# Altium schematic sheet MCU.SchDoc(MCU)
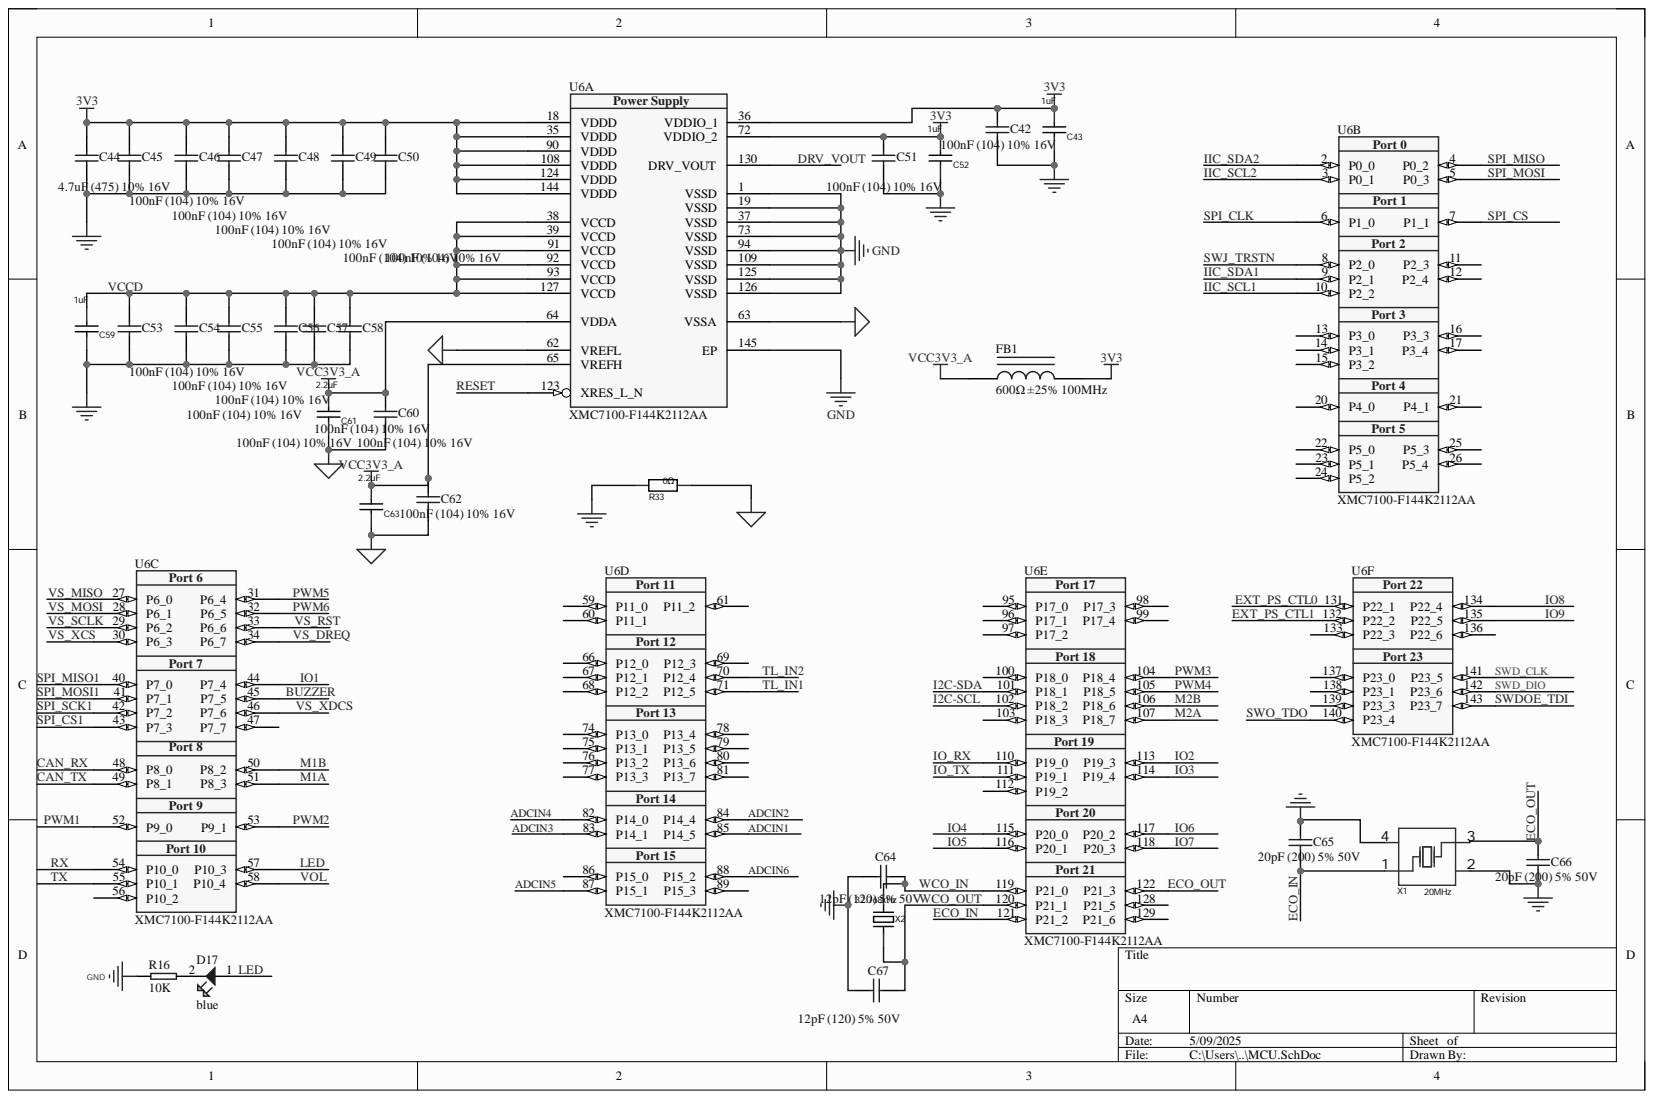
[diagram p0: MCU.SchDoc]

## Parts
C42  100nF (104) 10% 16V  [0402_C]
C43  1uF  Voltage Rated: 16V  [0402_C]
C44  4.7uF (475) 10% 16V  [0603_C]
C45  100nF (104) 10% 16V  [0402_C]
C46  100nF (104) 10% 16V  [0402_C]
C47  100nF (104) 10% 16V  [0402_C]
C48  100nF (104) 10% 16V  [0402_C]
C49  100nF (104) 10% 16V  [0402_C]
C50  100nF (104) 10% 16V  [0402_C]
C51  100nF (104) 10% 16V  [0402_C]
C52  1uF  Voltage Rated: 16V  [0402_C]
C53  100nF (104) 10% 16V  [0402_C]
C54  100nF (104) 10% 16V  [0402_C]
C55  100nF (104) 10% 16V  [0402_C]
C56  100nF (104) 10% 16V  [0402_C]
C57  100nF (104) 10% 16V  [0402_C]
C58  100nF (104) 10% 16V  [0402_C]
C59  1uF  [0402_C]
C60  100nF (104) 10% 16V  [0402_C]
C61  2.2uF  Voltage Rated: 16V  [0603_C]
C62  100nF (104) 10% 16V  [0402_C]
C63  2.2uF  [0603_C]
C64  12pF (120) 5% 50V  [0402_C]
C65  20pF (200) 5% 50V  [0402_C]
C66  20pF (200) 5% 50V  [0402_C]
C67  12pF (120) 5% 50V  [0402_C]
D17  blue  [LED0603-FD]
FB1  600Ω ±25% 100MHz  [0805C-GENERIC_2]
R16  10K  [R0603]
R33  0Ω  [R0402]
U6  XMC7100-F144K2112AA  [QFP50P2200X2200X160-145N-4-V1]  (parts A,B,C,D,E,F)
X1  20MHz  [CRYSTAL-SMD_4P-L3.2-W2.5-BL-2]
X2  32.768kHz  [OSC-SMD_L3.2-W1.5]

## Nets
3V3: C42.2 C43.2 C44.2 C45.2 C46.2 C47.2 C48.2 C49.2 C50.2 C51.2 C52.2 FB1.2 U6.18(VDDD) U6.35(VDDD) U6.36(VDDIO_1) U6.72(VDDIO_2) U6.90(VDDD) U6.108(VDDD) U6.124(VDDD) U6.144(VDDD)
AGND: C60.1 C61.1 C62.1 C63.1 R33.2 U6.62(VREFL) U6.63(VSSA)
GND: C42.1 C43.1 C44.1 C45.1 C46.1 C47.1 C48.1 C49.1 C50.1 C51.1 C52.1 C53.1 C54.1 C55.1 C56.1 C57.1 C58.1 C59.1 C64.1 C65.1 C66.2 C67.1 R16.2 R33.1 U6.1(VSSD) U6.19(VSSD) U6.37(VSSD) U6.73(VSSD) U6.94(VSSD) U6.109(VSSD) U6.125(VSSD) U6.126(VSSD) U6.145(EP) X1.2 X1.4
VCC3V3_A: C60.2 C61.2 C62.2 C63.2 FB1.1 U6.64(VDDA) U6.65(VREFH)
ADCIN1: U6.85(P14_5)
ADCIN2: U6.84(P14_4)
ADCIN3: U6.83(P14_1)
ADCIN4: U6.82(P14_0)
ADCIN5: U6.87(P15_1)
ADCIN6: U6.88(P15_2)
BUZZER: U6.45(P7_5)
CAN_RX: U6.48(P8_0)
CAN_TX: U6.49(P8_1)
DRV_VOUT: U6.130(DRV_VOUT)
ECO_IN: C65.2 U6.121(P21_2) X1.1
ECO_OUT: C66.1 U6.122(P21_3) X1.3
EXT_PS_CTL0: U6.131(P22_1)
EXT_PS_CTL1: U6.132(P22_2)
I2C-SCL: U6.102(P18_2)
I2C-SDA: U6.101(P18_1)
IIC_SCL1: U6.10(P2_2)
IIC_SCL2: U6.3(P0_1)
IIC_SDA1: U6.9(P2_1)
IIC_SDA2: U6.2(P0_0)
IO1: U6.44(P7_4)
IO2: U6.113(P19_3)
IO3: U6.114(P19_4)
IO4: U6.115(P20_0)
IO5: U6.116(P20_1)
IO6: U6.117(P20_2)
IO7: U6.118(P20_3)
IO8: U6.134(P22_4)
IO9: U6.135(P22_5)
IO_RX: U6.110(P19_0)
IO_TX: U6.111(P19_1)
LED: D17.1 U6.57(P10_3)
M1A: U6.51(P8_3)
M1B: U6.50(P8_2)
M2A: U6.107(P18_7)
M2B: U6.106(P18_6)
PWM1: U6.52(P9_0)
PWM2: U6.53(P9_1)
PWM3: U6.104(P18_4)
PWM4: U6.105(P18_5)
PWM5: U6.31(P6_4)
PWM6: U6.32(P6_5)
RESET: U6.123(XRES_L_N)
RX: U6.54(P10_0)
SPI_CLK: U6.6(P1_0)
SPI_CS: U6.7(P1_1)
SPI_CS1: U6.43(P7_3)
SPI_MISO: U6.4(P0_2)
SPI_MISO1: U6.40(P7_0)
SPI_MOSI: U6.5(P0_3)
SPI_MOSI1: U6.41(P7_1)
SPI_SCK1: U6.42(P7_2)
SWD_CLK: U6.141(P23_5)
SWD_DIO: U6.142(P23_6)
SWDOE_TDI: U6.143(P23_7)
SWJ_TRSTN: U6.8(P2_0)
SWO_TDO: U6.140(P23_4)
TL_IN1: U6.71(P12_5)
TL_IN2: U6.70(P12_4)
TX: U6.55(P10_1)
VCCD: C53.2 C54.2 C55.2 C56.2 C57.2 C58.2 C59.2 U6.38(VCCD) U6.39(VCCD) U6.91(VCCD) U6.92(VCCD) U6.93(VCCD) U6.127(VCCD)
VOL: U6.58(P10_4)
VS_DREQ: U6.34(P6_7)
VS_MISO: U6.27(P6_0)
VS_MOSI: U6.28(P6_1)
VS_RST: U6.33(P6_6)
VS_SCLK: U6.29(P6_2)
VS_XCS: U6.30(P6_3)
VS_XDCS: U6.46(P7_6)
WCO_IN: C64.2 U6.119(P21_0) X2.2
WCO_OUT: C67.2 U6.120(P21_1) X2.1
NetD17_2: D17.2 R16.1

Not connected: U6 11(P2_3) 12(P2_4) 13(P3_0) 14(P3_1) 15(P3_2) 16(P3_3) 17(P3_4) 20(P4_0) 21(P4_1) 22(P5_0) 23(P5_1) 24(P5_2) 25(P5_3) 26(P5_4) 47(P7_7) 56(P10_2) 59(P11_0) 60(P11_1) 61(P11_2) 66(P12_0) 67(P12_1) 68(P12_2) 69(P12_3) 74(P13_0) 75(P13_1) 76(P13_2) 77(P13_3) 78(P13_4) 79(P13_5) 80(P13_6) 81(P13_7) 86(P15_0) 89(P15_3) 95(P17_0) 96(P17_1) 97(P17_2) 98(P17_3) 99(P17_4) 100(P18_0) 103(P18_3) 112(P19_2) 128(P21_5) 129(P21_6) 133(P22_3) 136(P22_6) 137(P23_0) 138(P23_1) 139(P23_3)

## Library data of the parts
C42 C45-C51 C53-C58 C60 C62 = 0402 100nF (104) 10% 16V: 贴片电容; Component Kind: Standard; ComponentLink1Description: 供应商链接; ComponentLink1URL: http://www.szlcsc.com/product/details_1877.html; Library Name: Miscellaneous Devices LC.IntLib; Package: 0402; Supplier: LC; SuppliersPartNumber: C1525
C43 C52 = CL05A105KO5NNNC: Manufacturer: SAMSUNG(三星); Add into BOM: yes; Temperature Coefficient: X5R; Tolerance: ±10%; Component Kind: Standard; Convert to PCB: yes; Datasheet: https://atta.szlcsc.com/upload/public/pdf/source/20160218/1457707763339.pdf; Device: CL05A105KO5NNNC; JLCPCB Part Class: Extended Part; LCSC Part Name: 1uF ±10% 16V; Manufacturer Part: CL05A105KO5NNNC; PCB3D: C0402_L1.0-W0.5-H0.5; Supplier: LCSC; Supplier Footprint: 0402; Supplier Part: C29266; Symbol: CL05A105KO5NNNC; Value: 1uF
C44 = 0603 4.7uF (475) 10% 16V: 贴片电容; Component Kind: Standard; ComponentLink1Description: 供应商链接; ComponentLink1URL: http://www.szlcsc.com/product/details_20375.html; Library Name: Miscellaneous Devices LC.IntLib; Package: 0603; Supplier: LC; SuppliersPartNumber: C19666
C59 = CL05A105KO5NNNC: Manufacturer: SAMSUNG(三星); Add into BOM: yes; Temperature Coefficient: X5R; Tolerance: ±10%; Voltage Rated: 16V; Component Kind: Standard; Convert to PCB: yes; Datasheet: https://atta.szlcsc.com/upload/public/pdf/source/20160218/1457707763339.pdf; Device: CL05A105KO5NNNC; JLCPCB Part Class: Extended Part; LCSC Part Name: 1uF ±10% 16V; Manufacturer Part: CL05A105KO5NNNC; PCB3D: C0402_L1.0-W0.5-H0.5; Supplier: LCSC; Supplier Footprint: 0402; Supplier Part: C29266; Symbol: CL05A105KO5NNNC; Value: 1uF
C61 = CL10A225KO8NNNC: Manufacturer: SAMSUNG(三星); Add into BOM: yes; Temperature Coefficient: X5R; Tolerance: ±10%; Component Kind: Standard; Convert to PCB: yes; Datasheet: https://atta.szlcsc.com/upload/public/pdf/source/20160218/1457707763339.pdf; Device: CL10A225KO8NNNC; JLCPCB Part Class: Basic Part; LCSC Part Name: 2.2uF ±10% 16V; Manufacturer Part: CL10A225KO8NNNC; PCB3D: C0603_L1.6-W0.8-H0.8; Supplier: LCSC; Supplier Footprint: 0603; Supplier Part: C23630; Symbol: CL10A225KO8NNNC; Value: 2.2uF
C63 = CL10A225KO8NNNC: Manufacturer: SAMSUNG(三星); Add into BOM: yes; Temperature Coefficient: X5R; Tolerance: ±10%; Voltage Rated: 16V; Component Kind: Standard; Convert to PCB: yes; Datasheet: https://atta.szlcsc.com/upload/public/pdf/source/20160218/1457707763339.pdf; Device: CL10A225KO8NNNC; JLCPCB Part Class: Basic Part; LCSC Part Name: 2.2uF ±10% 16V; Manufacturer Part: CL10A225KO8NNNC; PCB3D: C0603_L1.6-W0.8-H0.8; Supplier: LCSC; Supplier Footprint: 0603; Supplier Part: C23630; Symbol: CL10A225KO8NNNC; Value: 2.2uF
C64 C67 = 0402 12pF (120) 5% 50V: 贴片电容; Component Kind: Standard; ComponentLink1Description: 供应商链接; ComponentLink1URL: http://www.szlcsc.com/product/details_1899.html; Library Name: Miscellaneous Devices LC.IntLib; Package: 0402; Supplier: LC; SuppliersPartNumber: C1547
C65 C66 = 0402 20pF (200) 5% 50V: 贴片电容; Component Kind: Standard; ComponentLink1Description: 供应商链接; ComponentLink1URL: http://www.szlcsc.com/product/details_1906.html; Library Name: Miscellaneous Devices LC.IntLib; Package: 0402; Supplier: LC; SuppliersPartNumber: C1554
D17 = LED-贴片: Component Kind: Standard; Library Name: CZ 3D.IntLib
FB1 = 0805 600Ω 25% 100MHz: 贴片磁珠; Component Kind: Standard; ComponentLink1Description: 供应商链接; ComponentLink1URL: http://www.szlcsc.com/product/details_1369.html; Library Name: Miscellaneous Devices LC.IntLib; Package: 0805; Supplier: LC; SuppliersPartNumber: C1017
R16 = Res: Component Kind: Standard; Library Name: CZ 3D.IntLib
R33 = 0402WGF0000TCE: 0欧  0402; Manufacturer: UNI-ROYAL(厚声); Add into BOM: yes; Overload Voltage (Max): 50V; Power(Watts): 62.5mW; Temperature Coefficient: ±800ppm/℃; Tolerance: ±1%; Component Kind: Standard; Convert to PCB: yes; Datasheet: https://atta.szlcsc.com/upload/public/pdf/source/20200306/C422600_1E6D84923E4A46A82E41ADD87F860B5C.pdf; Device: 0402WGF0000TCE; JLCPCB Part Class: Basic Part; LCSC Part Name: 0Ω ±1% 62.5mW 厚膜电阻; Library Name: Schlib1.SchLib; Manufacturer Part: 0402WGF0000TCE; Operating Temperature Range: -55℃~+155℃; PCB3D: R0402_L1.0-W0.5-H0.5; Supplier: LCSC; Supplier Footprint: 0402; Supplier Part: C17168; Symbol: 0402WGF0000TCE; Type: 厚膜电阻; Value: 0Ω
U6 = XMC7100-F144K2112AA: 32-bit  Automotive MCU Arm Cortex-M7 Microcontroller; Manufacturer: Infineon Technologies; Component Kind: Standard; Library Name: XMC7100-F144K2112AA.SchLib; Manufacturer Order Number: XMC7100-F144K2112AA; PackageReference: PG-TQFP-144-804
X1 = X322520MSB4SI: 20MHZ  4P 20pf无源晶振; Manufacturer: YXC(扬兴晶振); Add into BOM: yes; Frequency Stability: ±20ppm; Load Capacitance: 20pF; Normal temperature Frequency Tolerance: ±10ppm; Component Kind: Standard; Convert to PCB: yes; Datasheet: https://atta.szlcsc.com/upload/public/pdf/source/20240329/19D5F850207469550BEB00D7DFEDBC7A.pdf; Device: X322520MSB4SI; JLCPCB Part Class: Extended Part; LCSC Part Name: 20MHz ±10ppm 20pF; Library Name: Schlib1.SchLib; Manufacturer Part: X322520MSB4SI; Operating Temperature: -40℃~+85℃; PCB3D: CRYSTAL-SMD_4P-L3.2-W2.5-BL-2; Supplier: LCSC; Supplier Footprint: SMD3225-4P; Supplier Part: C9004; Symbol: X322520MSB4SI; Type: 贴片晶振; Value: 20MHz
X2 = X321532768KGD2SI: Manufacturer: YXC(扬兴晶振); Add into BOM: yes; Crystal Type: 贴片晶振; External load capacitor: 12.5pF; Normal temperature Frequency Tolerance: ±20ppm; Component Kind: Standard; Convert to PCB: yes; Datasheet: https://atta.szlcsc.com/upload/public/pdf/source/20240329/3A7650FD985DD0DE85694D3785AB4916.pdf; Device: X321532768KGD2SI; Frequency Stability(Full temperature range): -; JLCPCB Part Class: Extended Part; LCSC Part Name: 32.768kHz ±20ppm 12.5pF; Manufacturer Part: X321532768KGD2SI; Operating Temperature: -40℃~+85℃; PCB3D: OSC-SMD_L3.2-W1.5-H0.8; Supplier: LCSC; Supplier Footprint: SMD3215-2P; Supplier Part: C620155; Symbol: X321532768KGD2SI; Value: 32.768kHz
